# Altium schematic sheet 20_ATK_RK3588S_DEVICE0.SchDoc
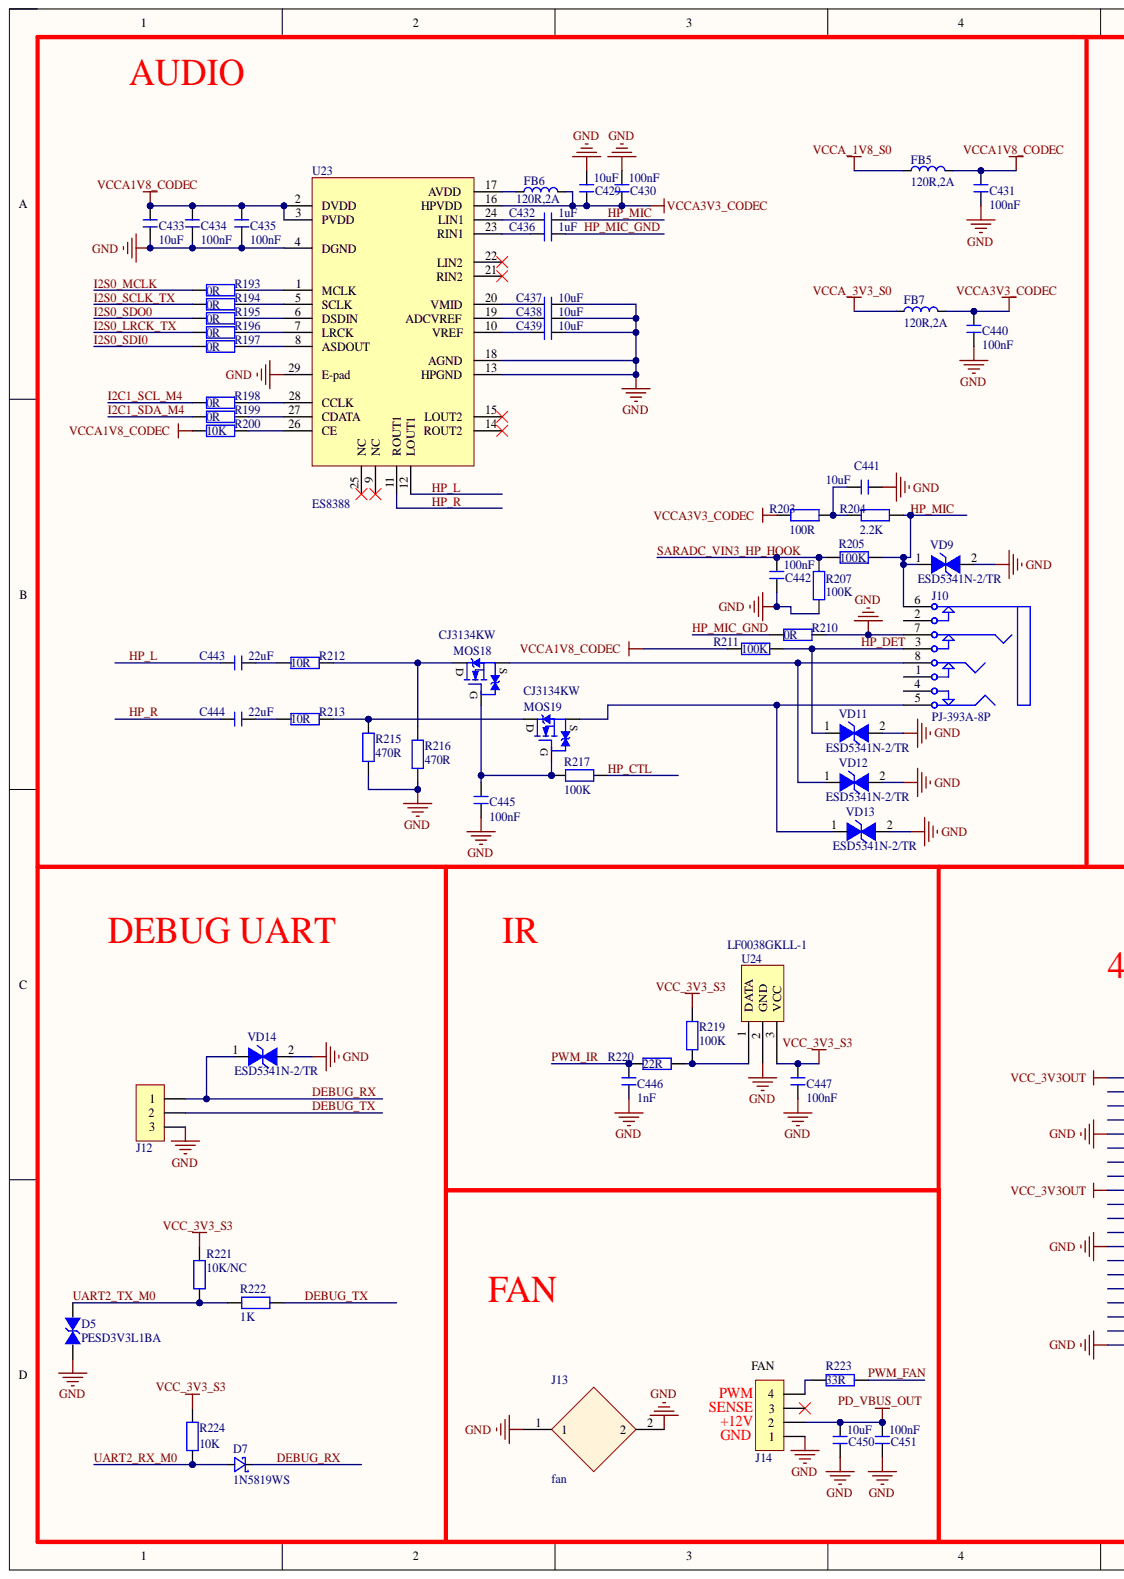
[diagram p0: 20_ATK_RK3588S_DEVICE0.SchDoc, left]
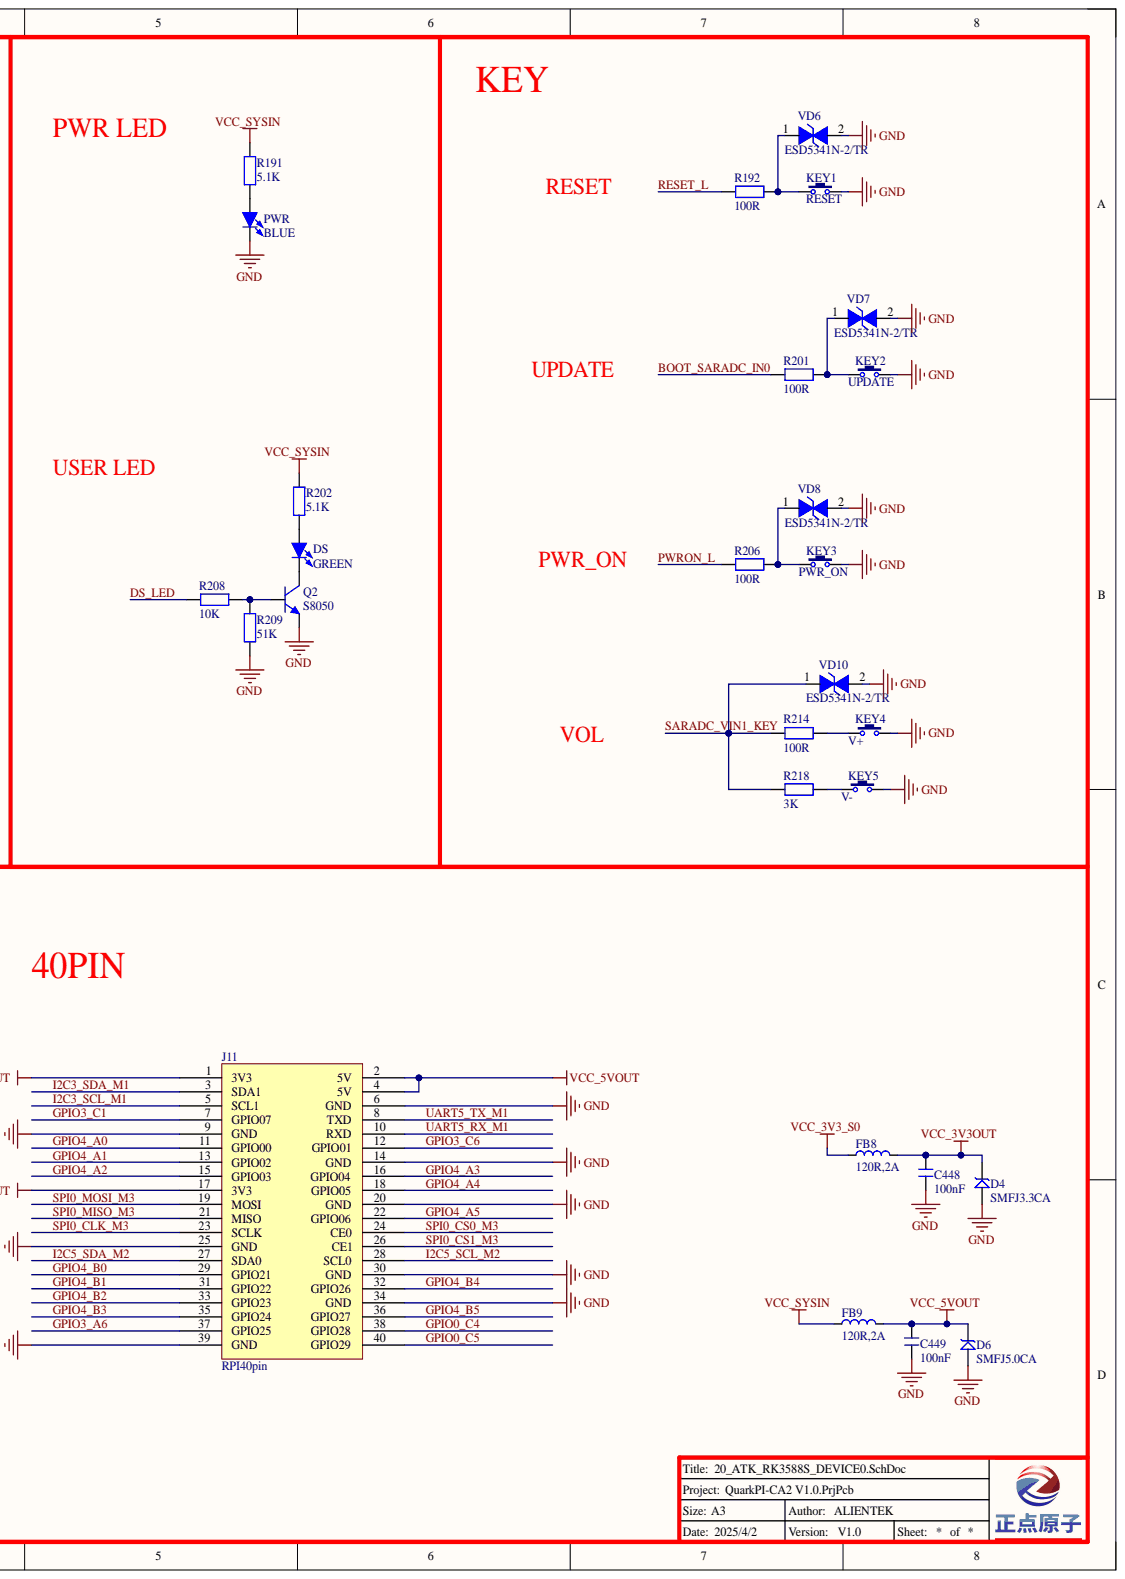
[diagram p1: 20_ATK_RK3588S_DEVICE0.SchDoc, right]

## Parts
C429  10uF  [C 0402]
C430  100nF  [C 0201]
C431  100nF  [C 0201]
C432  1uF  [C 0201]
C433  10uF  [C 0402]
C434  100nF  [C 0201]
C435  100nF  [C 0201]
C436  1uF  [C 0201]
C437  10uF  [C 0402]
C438  10uF  [C 0402]
C439  10uF  [C 0402]
C440  100nF  [C 0201]
C441  10uF  [C 0402]
C442  100nF  [C 0201]
C443  22uF  [C 0805]
C444  22uF  [C 0805]
C445  100nF  [C 0402]
C446  1nF  [C 0201]
C447  100nF  [C 0201]
C448  100nF  [C 0201]
C449  100nF  [C 0201]
C450  10uF  [C 0402]
C451  100nF  [C 0201]
D4  SMFJ3.3CA  [SOD-123]
D5  PESD3V3L1BA  [SOD-323]
D6  SMFJ5.0CA  [SOD-123]
D7  1N5819WS  [SOD-323]
DS  GREEN  [LED 0602]
FB5  120R,2A  [L 0603]
FB6  120R,2A  [L 0603]
FB7  120R,2A  [L 0603]
FB8  120R,2A  [L 0603]
FB9  120R,2A  [L 0603]
J10  PJ-393A-8P  [PJ-393A-8P]
J11  RPI40pin  [RPI40Pin]
J12  Header 1X3  [Header 2.54 1X3P]  (value not drawn)
J13  fan  [3588 fan]
J14  Header 1X4  [PH2.0-4P V-T]  (value not drawn)
KEY1  RESET  [SW-TH_TS-1091B]
KEY2  UPDATE  [SW-TH_TS-1091B]
KEY3  PWR_ON  [KEY_SOP324225]
KEY4  V+  [KEY_SOP324225]
KEY5  V-  [KEY_SOP324225]
MOS18  CJ3134KW  [SOT323-3L]
MOS19  CJ3134KW  [SOT323-3L]
PWR  BLUE  [LED 0602]
Q2  S8050  [SOT23-3N]
R191  5.1K  [R 0402]
R192  100R  [R 0402]
R193  0R  [R 0402]
R194  0R  [R 0402]
R195  0R  [R 0402]
R196  0R  [R 0402]
R197  0R  [R 0402]
R198  0R  [R 0402]
R199  0R  [R 0402]
R200  10K  [R 0402]
R201  100R  [R 0402]
R202  5.1K  [R 0402]
R203  100R  [R 0402]
R204  2.2K  [R 0402]
R205  100K  [R 0402]
R206  100R  [R 0402]
R207  100K  [R 0402]
R208  10K  [R 0402]
R209  51K  [R 0402]
R210  0R  [R 0402]
R211  100K  [R 0402]
R212  10R  [R 0402]
R213  10R  [R 0402]
R214  100R  [R 0402]
R215  470R  [R 0402]
R216  470R  [R 0402]
R217  100K  [R 0402]
R218  3K  [R 0402]
R219  100K  [R 0402]
R220  22R  [R 0402]
R221  10K/NC  [R 0402]
R222  1K  [R 0402]
R223  33R  [R 0402]
R224  10K  [R 0402]
U23  ES8388  [QFN28 4X4_L]
U24  LF0038GKLL-1  [LF0038GKLL-1]
VD6  ESD5341N-2/TR  [ESD0402]
VD7  ESD5341N-2/TR  [ESD0402]
VD8  ESD5341N-2/TR  [ESD0402]
VD9  ESD5341N-2/TR  [ESD0402]
VD10  ESD5341N-2/TR  [ESD0402]
VD11  ESD5341N-2/TR  [ESD0402]
VD12  ESD5341N-2/TR  [ESD0402]
VD13  ESD5341N-2/TR  [ESD0402]
VD14  ESD5341N-2/TR  [ESD0402]

## Nets
GND: C429.2 C430.2 C431.1 C433.1 C434.1 C435.1 C437.1 C438.1 C439.1 C440.1 C441.1 C442.1 C445.1 C446.1 C447.1 C448.1 C449.1 C450.1 C451.1 D4.1 D5.1 D6.1 J10.7 J11.6(GND) J11.9(GND) J11.14(GND) J11.20(GND) J11.25(GND) J11.30(GND) J11.34(GND) J11.39(GND) J12.3 J13.1 J13.2 J14.1 KEY1.2 KEY2.2 KEY3.2 KEY4.2 KEY5.2 PWR.2 Q2.2 R207.1 R209.1 R210.2 R215.1 R216.1 U23.4(DGND) U23.13(HPGND) U23.18(AGND) U23.29(E-pad) U24.2 VD6.2 VD7.2 VD8.2 VD9.2 VD10.2 VD11.2 VD12.2 VD13.2 VD14.2
PD_VBUS_OUT: C450.2 C451.2 J14.2
VCC_3V3_S0: FB8.1
VCC_3V3_S3: C447.2 R219.1 R221.2 R224.2 U24.3
VCC_3V3OUT: C448.2 D4.2 FB8.2 J11.1(3V3) J11.17(3V3)
VCC_5VOUT: C449.2 D6.2 FB9.2 J11.2(5V) J11.4(5V)
VCC_SYSIN: FB9.1 R191.2 R202.2
VCCA1V8_CODEC: C431.2 C433.2 C434.2 C435.2 FB5.2 R200.1 R211.2 U23.2(DVDD) U23.3(PVDD)
VCCA3V3_CODEC: C429.1 C430.1 C440.2 FB6.2 FB7.2 R203.2 U23.16(HPVDD)
VCCA_1V8_S0: FB5.1
VCCA_3V3_S0: FB7.1
BOOT_SARADC_IN0: R201.1
DEBUG_RX: D7.2 J12.1 VD14.1
DEBUG_TX: J12.2 R222.1
DS_LED: R208.2
GPIO0_C4: J11.38(GPIO28)
GPIO0_C5: J11.40(GPIO29)
GPIO3_A6: J11.37(GPIO25)
GPIO3_C1: J11.7(GPIO07)
GPIO3_C6: J11.12(GPIO01)
GPIO4_A0: J11.11(GPIO00)
GPIO4_A1: J11.13(GPIO02)
GPIO4_A2: J11.15(GPIO03)
GPIO4_A3: J11.16(GPIO04)
GPIO4_A4: J11.18(GPIO05)
GPIO4_A5: J11.22(GPIO06)
GPIO4_B0: J11.29(GPIO21)
GPIO4_B1: J11.31(GPIO22)
GPIO4_B2: J11.33(GPIO23)
GPIO4_B3: J11.35(GPIO24)
GPIO4_B4: J11.32(GPIO26)
GPIO4_B5: J11.36(GPIO27)
HP_CTL: R217.2
HP_DET: J10.3 R211.1 VD11.1
HP_L: C443.2 U23.12(LOUT1)
HP_MIC: C432.1 J10.6 R204.1 R205.1 VD9.1
HP_MIC_GND: C436.1 R210.1
HP_R: C444.2 U23.11(ROUT1)
I2C1_SCL_M4: R198.1
I2C1_SDA_M4: R199.1
I2C3_SCL_M1: J11.5(SCL1)
I2C3_SDA_M1: J11.3(SDA1)
I2C5_SCL_M2: J11.28(SCL0)
I2C5_SDA_M2: J11.27(SDA0)
I2S0_LRCK_TX: R196.1
I2S0_MCLK: R193.1
I2S0_SCLK_TX: R194.1
I2S0_SDI0: R197.1
I2S0_SDO0: R195.1
PWM_FAN: R223.1
PWM_IR: C446.2 R220.2
PWRON_L: R206.1
RESET_L: R192.1
SARADC_VIN1_KEY: R214.1 R218.2 VD10.1
SARADC_VIN3_HP_HOOK: C442.2 R205.2 R207.2
SPI0_CLK_M3: J11.23(SCLK)
SPI0_CS0_M3: J11.24(CE0)
SPI0_CS1_M3: J11.26(CE1)
SPI0_MISO_M3: J11.21(MISO)
SPI0_MOSI_M3: J11.19(MOSI)
UART2_RX_M0: D7.1 R224.1
UART2_TX_M0: D5.2 R221.1 R222.2
UART5_RX_M1: J11.10(RXD)
UART5_TX_M1: J11.8(TXD)
NetC432_2: C432.2 U23.24(LIN1)
NetC436_2: C436.2 U23.23(RIN1)
NetC437_2: C437.2 U23.20(VMID)
NetC438_2: C438.2 U23.19(ADCVREF)
NetC439_2: C439.2 U23.10(VREF)
NetC441_2: C441.2 R203.1 R204.2
NetC443_1: C443.1 R212.1
NetC444_1: C444.1 R213.1
NetC445_2: C445.2 MOS18.1 MOS19.1 R217.1
NetDS_1: DS.1 R202.1
NetDS_2: DS.2 Q2.3
NetFB6_1: FB6.1 U23.17(AVDD)
NetJ10_5: J10.5 MOS19.2 VD13.1
NetJ10_8: J10.8 MOS18.2 VD12.1
NetJ14_4: J14.4 R223.2
NetKEY1_1: KEY1.1 R192.2 VD6.1
NetKEY2_1: KEY2.1 R201.2 VD7.1
NetKEY3_1: KEY3.1 R206.2 VD8.1
NetKEY4_1: KEY4.1 R214.2
NetKEY5_1: KEY5.1 R218.1
NetMOS18_3: MOS18.3 R212.2 R216.2
NetMOS19_3: MOS19.3 R213.2 R215.2
NetPWR_1: PWR.1 R191.1
NetQ2_1: Q2.1 R208.1 R209.2
NetR193_2: R193.2 U23.1(MCLK)
NetR194_2: R194.2 U23.5(SCLK)
NetR195_2: R195.2 U23.6(DSDIN)
NetR196_2: R196.2 U23.7(LRCK)
NetR197_2: R197.2 U23.8(ASDOUT)
NetR198_2: R198.2 U23.28(CCLK)
NetR199_2: R199.2 U23.27(CDATA)
NetR200_2: R200.2 U23.26(CE)
NetR219_2: R219.2 R220.1 U24.1

Not connected: J10 1 2 4; J14 3; U23 9(NC) 14(ROUT2) 15(LOUT2) 21(RIN2) 22(LIN2) 25(NC)

## Library data of the parts
C429-C451 = C: 无极性电容; BOM名称: 无极性贴片电容; 版权归属: 正点原子; Component Kind: Standard; Library Name: 正点原子封装库V1.0.IntLib; 学习资源: www.openedv.com
D4 = SMBJ_1: TVS; Component Kind: Standard; Library Name: AtomPi-CA1 V1.1.IntLib
D5 = PESD5V0L1BA: ESD-静电保护TVS管; Component Kind: Standard; Library Name: 正点原子封装库V1.0.IntLib
D6 = SMBJ: TVS; Component Kind: Standard; Library Name: AtomPi-CA1 V1.1.IntLib
D7 = 1N5819HW-7-F: 肖特基二极管 40V  450mV@1A; 版权归属: 正点原子; Component Kind: Standard; Library Name: ATK-DLRK3588B V0.2.IntLib; Parameter: 肖特基二极管; 学习资源: www.openedv.com
DS = LED-SMD_1: 贴片LED; Parameter: 发光二极管; 版权归属: 正点原子; BOM名称: 贴片LED; Component Kind: Standard; 学习资源: www.openedv.com; Library Name: AtomPi-CA1 V1.1.IntLib
FB5-FB9 = FB: 贴片磁珠; BOM名称: 小功率贴片电感; Parameter: 电感/磁珠; 版权归属: 正点原子; Component Kind: Standard; Library Name: 正点原子封装库V1.0.IntLib; 学习资源: www.openedv.com
J11 = RPI-40Pin: Component Kind: Standard; Library Name: AtomPi-CA1 V1.1.IntLib
J12 = Header 3: 3P接插件; Parameter: 接插件; 版权归属: 正点原子; BOM名称: 3P接插件; Component Kind: Standard; 学习资源: www.openedv.com; Library Name: AtomPi-CA1 V1.1.IntLib
J14 = Header 4: 4P接插件; Parameter: 接插件; 版权归属: 正点原子; BOM名称: 4P接插件; Component Kind: Standard; Library Name: 正点原子封装库V1.0.IntLib; 学习资源: www.openedv.com
KEY1-KEY5 = KEY: 按键; BOM名称: 3x6轻触开关; 版权归属: 正点原子; Component Kind: Standard; 学习资源: www.openedv.com; Library Name: AtomPi-CA1 V1.1.IntLib
MOS18 MOS19 = 2SK3018: NMOS  30V 0.1A@2.5V 8Ω; BOM名称: N沟场效应管; Parameter: MOS管; 版权归属: 正点原子; Component Kind: Standard; Library Name: ATK-DLRK3588B V0.2.IntLib; 学习资源: www.openedv.com
PWR = LED-SMD: 贴片LED; 版权归属: 源创客; BOM名称: 贴片LED; Component Kind: Standard; 学习资源: www.ooocke.com; Library Name: AtomPi-CA1 V1.1.IntLib
Q2 = 8050-SMD: 高频放大-NPN型; Parameter: 三极管; 属性: 40V 1.5A 1W 100MHZ; 版权归属: 正点原子; BOM名称: 高频放大-NPN型; Component Kind: Standard; Library Name: 正点原子封装库V1.0.IntLib; 学习资源: www.openedv.com
R191-R224 = R: 电阻; BOM名称: 贴片电阻; 版权归属: 正点原子; Component Kind: Standard; Library Name: 正点原子封装库V1.0.IntLib; Parameter: 电阻; 学习资源: www.openedv.com
U24 = HS0038: Component Kind: Standard; Library Name: AtomPi-CA1 V1.1.IntLib
VD6-VD14 = ESD5341N: ESD二极管-单向; Component Kind: Standard; Library Name: ATK-DLRK3588B V0.2.IntLib
J10 = PJ-393A-8P: Component Kind: Standard; Library Name: atompi-ca2.SchLib
J13 = fan: Component Kind: Standard; Library Name: AtomPi-CA1 V1.1.IntLib
U23 = ES8388: Component Kind: Standard; Library Name: ATK-DLRK3588B V0.2.IntLib
